# Altium schematic sheet Control_Schem.SchDoc(Control_Schem) (continued)
## Library data of the parts (continued)
U1 = BNO055: IMU ACCEL/GYRO/MAG I2C 28LGA / Intelligent 9-axis absolute orientation sensor; Manufacturer: Bosch Sensortec; Interface: I2C, UART; Max Supply Voltage: 3.6V; Min Supply Voltage: 1.7V; Operating Supply Current: 12.3mA; Ambient Temperature Range High: 85°C; Availability: Unavailable; Category: Inertial Measurement Units (IMUs); Component Kind: Standard; ComponentLink1Description: https://datasheet.octopart.com:443/BNO055-Bosch-Sensortec-datasheet-127364294.pdf?src-supplier=LKR; ComponentLink1URL: https://datasheet.octopart.com:443/BNO055-Bosch-Sensortec-datasheet-127364294.pdf?src-supplier=LKR; ComponentLink2Description: https://sigma.octopart.com:443/141945489/technical_drawing/Bosch-Sensortec-BNO055.pdf?src-supplier=Upverter; ComponentLink2URL: https://sigma.octopart.com:443/141945489/technical_drawing/Bosch-Sensortec-BNO055.pdf?src-supplier=Upverter; ComponentLink3Description: https://datasheet.octopart.com:443/BNO055-Bosch-Sensortec-datasheet-99733204.pdf?src-supplier=Upverter; ComponentLink3URL: https://datasheet.octopart.com:443/BNO055-Bosch-Sensortec-datasheet-99733204.pdf?src-supplier=Upverter; ComponentLink4Description: https://datasheet.octopart.com:443/BNO055-Bosch-Sensortec-datasheet-97529823.pdf?src-supplier=Upverter; ComponentLink4URL: https://datasheet.octopart.com:443/BNO055-Bosch-Sensortec-datasheet-97529823.pdf?src-supplier=Upverter; ComponentLink5Description: https://datasheet.octopart.com:443/BNO055-Bosch-Sensortec-datasheet-37535188.pdf?src-supplier=Upverter; ComponentLink5URL: https://datasheet.octopart.com:443/BNO055-Bosch-Sensortec-datasheet-37535188.pdf?src-supplier=Upverter; ComponentLink6Description: https://datasheet.octopart.com:443/BNO055-Bosch-Sensortec-datasheet-181953299.pdf?src-supplier=Future+Electronics; ComponentLink6URL: https://datasheet.octopart.com:443/BNO055-Bosch-Sensortec-datasheet-181953299.pdf?src-supplier=Future+Electronics; Height: 1.18mm; Library Name: Bosch_Sensor.SchLib; Manufacturer Part Number: BNO055; Max Operating Temperature: 85°C; Min Operating Temperature: -40°C; MP: BNO055; Package: None; Pins: 2; Price: None; Pricing: 4000=10.57 (USD); RoHS: Compliant; Supplier 1: Future Electronics; Supplier Part Number 1: BNO055
U2 = STM32F411RET6TR: RISC Microcontroller, 32-Bit, FLASH, CORTEX-M4 CPU, 100MHz, CMOS, PQFP64; Manufacturer: STMicroelectronics; ADC Channels (Resolution): 16-Ch 12-Bit; Clock Frequency: 26MHz; Core Architecture: ARM Cortex-M4; EEPROM Memory Size: 0B; Flash Memory Size: 512kB; Geometry.Height: 1.6mm; Interface: SDIO, USB; Max Supply Current: 34.5mA; Max Supply Voltage: 3.6V; Min Supply Voltage: 1.7V; Nominal Supply Current: 1.8µA; Number of A/D Converters: 1; Number of Channels: 16; Number of I2C Channels: 3; Number of I/Os: 50; Number of SPI Channels: 5; Number of Timers/Counters: 2; Operating Supply Voltage: 3.3V; Peripherals: POR; RAM Size: 128kB; Arrow Part Number: STM32F411RET6TR; Arrow Price/Stock: https://www.arrow.com/en/products/stm32f411ret6tr/stmicroelectronics?utm_currency=USD&region=nac; Case/Package: LFQFP; Category: Microcontrollers; China RoHS: Compliant; Component Kind: Standard; ComponentLink1Description: https://datasheet.octopart.com:443/STM32F411RET6TR-STMicroelectronics-datasheet-41919523.pdf?src-supplier=Upverter; ComponentLink1URL: https://datasheet.octopart.com:443/STM32F411RET6TR-STMicroelectronics-datasheet-41919523.pdf?src-supplier=Upverter; ComponentLink2Description: https://datasheet.octopart.com:443/STM32F411RET6TR-STMicroelectronics-datasheet-27102711.pdf?src-supplier=STMicroelectronics; ComponentLink2URL: https://datasheet.octopart.com:443/STM32F411RET6TR-STMicroelectronics-datasheet-27102711.pdf?src-supplier=STMicroelectronics; ComponentLink3Description: https://datasheet.octopart.com:443/STM32F411RET6TR-STMicroelectronics-datasheet-82511007.pdf?src-supplier=SHENGYU+ELECTRONICS; ComponentLink3URL: https://datasheet.octopart.com:443/STM32F411RET6TR-STMicroelectronics-datasheet-82511007.pdf?src-supplier=SHENGYU+ELECTRONICS; Country of Origin: Mainland China, Malaysia, Taiwan; Datasheet Link: https://www.st.com/resource/en/datasheet/stm32f411ce.pdf; Export Control Classification Number (ECCN) Code: 3A991.A.2; Frequency: 100MHz; Harmonized Tariff Schedule (HTS) Code: 8542.31.00.25; Height - Seated (Max): 1.6mm; Introduction Date: 2014-06-19; Length: 10mm; Library Name: ST_Microcontroller.SchLib; Lifecycle Status: Production; Manufacturer Part Number: STM32F411RET6TR; Manufacturer_Name: STMicroelectronics; Manufacturer_Part_Number: STM32F411RET6TR; Max Operating Temperature: 85°C; Memory Format: FLASH; Min Operating Temperature: -40°C; Mouser Part Number: 511-STM32F411RET6TR; Mouser Price/Stock: https://www.mouser.co.uk/ProductDetail/STMicroelectronics/STM32F411RET6TR?qs=BA62vJVifGpaf6czDatkOw%3D%3D; Number of Interrupts: 16; Number of Terminals: 64; Number of USART Channels: 3; Packaging: Tape and Reel; Pricing: 1=6.84, 10=5.258, 25=4.864, 100=4.4302, 250=4.2442 (USD); REACH SVHC: Yes; RoHS: Compliant; Stock: 34; Supplier 1: DigiKey; Supplier Part Number 1: 497-19349-1-ND; Temperature Grade: Industrial; Terminal Pitch: 500µm; Width: 10mm
U3 U4 = DRV8231ADSGR: 50-V, 4.1-A H-bridge motor driver with integrated current regulation & current-sense feedback 8-SO PowerPAD -40 to 150; Manufacturer: Texas Instruments; Manufacturer Lifecycle Status: ACTIVE; Number of Channels: 1; Category: Motor Drivers; Component Kind: Standard; ComponentLink2Description: https://datasheet.octopart.com:443/DRV8251ADDAR-Texas-Instruments-datasheet-165811235.pdf?src-supplier=ODG+(Origin+Data+Global); ComponentLink2URL: https://datasheet.octopart.com:443/DRV8251ADDAR-Texas-Instruments-datasheet-165811235.pdf?src-supplier=ODG+(Origin+Data+Global); ComponentLink3Description: https://datasheet.octopart.com:443/DRV8251ADDAR-Texas-Instruments-datasheet-178830255.pdf?src-supplier=LCSC; ComponentLink3URL: https://datasheet.octopart.com:443/DRV8251ADDAR-Texas-Instruments-datasheet-178830255.pdf?src-supplier=LCSC; ComponentLink4Description: http://sigma.octopart.com:80/reach_statement/Texas-Instruments-company-64.pdf?src-supplier=Compliance+Statements; ComponentLink4URL: http://sigma.octopart.com:80/reach_statement/Texas-Instruments-company-64.pdf?src-supplier=Compliance+Statements; ComponentLink5Description: http://sigma.octopart.com:80/conflict_mineral_statement/Texas-Instruments-company-63.pdf?src-supplier=Compliance+Statements; ComponentLink5URL: http://sigma.octopart.com:80/conflict_mineral_statement/Texas-Instruments-company-63.pdf?src-supplier=Compliance+Statements; Height: 1.7mm; Length: 4.89mm; Lifecycle Status: Production; Manufacturer Part Number: DRV8251ADDAR; Pins: 8; Pricing: 1=2.25, 10=1.665, 25=1.5196, 100=1.3591, 250=1.28268, 500=1.2366, 1000=1.19866 (USD); RoHS: Compliant; Stock: 6728; Supplier 1: DigiKey; Supplier Part Number 1: 296-DRV8251ADDARCT-ND; Thickness: 1.48mm; Width: 3.9mm; Library Name: Motion.SchLib
  U3: ComponentLink1Description: https://octopart.com:443/opatz8j6/c1?t=7oUKRKkqwphIgwbtNbBc67owxNExsRdXrlPd_sBHnIKi_-H_t5suGGb5ZND4dBnSpUprWKEI1GJbY9qXDqE5wQb54uF2_8cCtleVlQfIIUgNQal_f1XPPx41JvHuqZD8P686xjzcz29DRRHUNopMHhQt6Aun-Q7UtO3W5-WTfdM8Hgmb8mq14zsLVaf9BYQF4kWKKP1vJII8XnOUC2yw6q8reuoLVzCQkwxpJfqf3XVhJXuLLQnsgXc5Zvxap5_H4ldeiGenDzkE71_iLZElw_4WjFZC3t3acjBfqrvQXTF1uguQZXqacgCm7W9f8tf9yLwhTR4Pgx-V1MzeuQJKKgipRE97MCy_LxstRkR3sNYpAlDTbjfaO7-AnMMibebzN-J1q-mELw&src-supplier=Texas+Instruments; ComponentLink1URL: https://octopart.com:443/opatz8j6/c1?t=7oUKRKkqwphIgwbtNbBc67owxNExsRdXrlPd_sBHnIKi_-H_t5suGGb5ZND4dBnSpUprWKEI1GJbY9qXDqE5wQb54uF2_8cCtleVlQfIIUgNQal_f1XPPx41JvHuqZD8P686xjzcz29DRRHUNopMHhQt6Aun-Q7UtO3W5-WTfdM8Hgmb8mq14zsLVaf9BYQF4kWKKP1vJII8XnOUC2yw6q8reuoLVzCQkwxpJfqf3XVhJXuLLQnsgXc5Zvxap5_H4ldeiGenDzkE71_iLZElw_4WjFZC3t3acjBfqrvQXTF1uguQZXqacgCm7W9f8tf9yLwhTR4Pgx-V1MzeuQJKKgipRE97MCy_LxstRkR3sNYpAlDTbjfaO7-AnMMibebzN-J1q-mELw&src-supplier=Texas+Instruments
  U4: ComponentLink1Description: https://octopart.com:443/opatz8j6/c1?t=Gj9IDkYE8sfDnI1AQ8OPnHqI7H6pSVf5TjQsrTRNSfFb2i9Q4E7y6UU0tVNpv_uoOppLbHDr29Be0S0k4dsXsBj-9gVok0f8TON-7LqCOQIvnQH5XUUSK6qV1XxCoSC3IIyjzOJDbsjsD30C2G81fOEWgdAi9tTEL0YTqVgBlAxJkPUr1oD_orBTcTOlqy8LQjJndhkQh3dsRqZAKQuzGR54t0CVOThOeZ_uUhCqRvIOoCKY4GRRNIIIiOkvYVDQVjZDrzRxciuayJCFmhWe2bbu2HGZQ9cORHH4HLGk37RopXHYscM4bRc45aOsixNwKVZGCHcT521wi6UN6PXFqU74gjaycJJAvUxioH527eZvfdREOqnlpyx5aqlzelpdWEqVmK_K5A&src-supplier=Texas+Instruments; ComponentLink1URL: https://octopart.com:443/opatz8j6/c1?t=Gj9IDkYE8sfDnI1AQ8OPnHqI7H6pSVf5TjQsrTRNSfFb2i9Q4E7y6UU0tVNpv_uoOppLbHDr29Be0S0k4dsXsBj-9gVok0f8TON-7LqCOQIvnQH5XUUSK6qV1XxCoSC3IIyjzOJDbsjsD30C2G81fOEWgdAi9tTEL0YTqVgBlAxJkPUr1oD_orBTcTOlqy8LQjJndhkQh3dsRqZAKQuzGR54t0CVOThOeZ_uUhCqRvIOoCKY4GRRNIIIiOkvYVDQVjZDrzRxciuayJCFmhWe2bbu2HGZQ9cORHH4HLGk37RopXHYscM4bRc45aOsixNwKVZGCHcT521wi6UN6PXFqU74gjaycJJAvUxioH527eZvfdREOqnlpyx5aqlzelpdWEqVmK_K5A&src-supplier=Texas+Instruments
U5 = W25Q128JVSIQ: NOR Flash Serial (SPI, Dual SPI, Quad SPI) 3.3V 128M-bit 16M x 8 6ns 8-Pin SOIC Tube; Manufacturer: Winbond; Clock Frequency: 133MHz; Frequency: 104MHz; Max Supply Current: 20mA; Max Supply Voltage: 3.6V; Memory Size: 16MB; Min Supply Voltage: 2.7V; Number of Circuits: 1; Operating Supply Voltage: 3V; Ambient Temperature Range High: 85°C; Case/Package: SOP; Category: Flash; China RoHS: Compliant; Component Kind: Standard; ComponentLink1Description: https://datasheet.octopart.com:443/W25Q128JVSIQ-Winbond-datasheet-181529389.pdf?src-supplier=ODG+(Origin+Data+Global); ComponentLink1URL: https://datasheet.octopart.com:443/W25Q128JVSIQ-Winbond-datasheet-181529389.pdf?src-supplier=ODG+(Origin+Data+Global); ComponentLink2Description: https://sigma.octopart.com:443/138898685/technical_drawing/Winbond-W25Q128JVSIQ.pdf?src-supplier=Upverter; ComponentLink2URL: https://sigma.octopart.com:443/138898685/technical_drawing/Winbond-W25Q128JVSIQ.pdf?src-supplier=Upverter; ComponentLink3Description: https://datasheet.octopart.com:443/W25Q128JVSIQ-Winbond-datasheet-138898757.pdf?src-supplier=Upverter; ComponentLink3URL: https://datasheet.octopart.com:443/W25Q128JVSIQ-Winbond-datasheet-138898757.pdf?src-supplier=Upverter; ComponentLink4Description: https://datasheet.octopart.com:443/W25Q128JVSIQ-Winbond-datasheet-138104337.pdf?src-supplier=Augswan; ComponentLink4URL: https://datasheet.octopart.com:443/W25Q128JVSIQ-Winbond-datasheet-138104337.pdf?src-supplier=Augswan; Export Control Classification Number (ECCN) Code: EAR99; Harmonized Tariff Schedule (HTS) Code: 8542.32.00.51; Height: 2.16mm; Height - Seated (Max): 2.16mm; Interface: Serial; Introduction Date: 2016-11-14; Length: 5.23mm; Library Name: Memory.SchLib; Lifecycle Status: Production; Manufacturer Part Number: W25Q128JVSIQ; Max Operating Temperature: 85°C; Memory Format: FLASH; Min Operating Temperature: -40°C; Number of Terminals: 8; Pricing: 1=2.24, 10=2.097, 25=2.0376, 90=1.95322, 180=1.90739, 270=1.88059, 540=1.83506, 1080=1.78986, 5040=1.69147 (USD); REACH SVHC: Yes; RoHS: Compliant; Schedule B: 8542320070, 8542320070|8542320070, 8542320070|8542320070|8542320070, 8542320070|8542320070|8542320070|8542320070; Supplier 1: DigiKey; Supplier Part Number 1: W25Q128JVSIQ-ND; Temperature Grade: Industrial; Terminal Pitch: 1.27mm; Width: 5.23mm
X1 = XTAL-2P: Crystal 0.032768MHz ±20ppm (Tol) 12.5pF Flexural 70000Ohm 2-Pin CSMD T/R; Manufacturer: Abracon; Manufacturer Part Number: ABS07-32.768KHZ-T; ESR: 70kΩ; Frequency: 32.768kHz; Frequency Stability: 144ppm; Frequency Tolerance: 0.002%; Case/Package: CSMD; Category: Crystals; China RoHS: Compliant; Component Kind: Standard; ComponentLink1Description: https://datasheet.octopart.com:443/ABS07-32.768KHZ-T-Abracon-datasheet-166693031.pdf?src-supplier=JRH+Electronics; ComponentLink1URL: https://datasheet.octopart.com:443/ABS07-32.768KHZ-T-Abracon-datasheet-166693031.pdf?src-supplier=JRH+Electronics; ComponentLink2Description: https://datasheet.octopart.com:443/ABS07-32.768KHZ-T-Abracon-datasheet-103422647.pdf?src-supplier=JRH+Electronics; ComponentLink2URL: https://datasheet.octopart.com:443/ABS07-32.768KHZ-T-Abracon-datasheet-103422647.pdf?src-supplier=JRH+Electronics; ComponentLink3Description: https://datasheet.octopart.com:443/ABS07-32.768KHZ-T-Abracon-datasheet-12512028.pdf?src-supplier=Upverter; ComponentLink3URL: https://datasheet.octopart.com:443/ABS07-32.768KHZ-T-Abracon-datasheet-12512028.pdf?src-supplier=Upverter; ComponentLink4Description: https://datasheet.octopart.com:443/ABS07-32.768KHZ-T-Abracon-datasheet-10031075.pdf?src-supplier=Upverter; ComponentLink4URL: https://datasheet.octopart.com:443/ABS07-32.768KHZ-T-Abracon-datasheet-10031075.pdf?src-supplier=Upverter; ComponentLink5Description: https://datasheet.octopart.com:443/ABS07-32.768KHZ-T-Abracon-datasheet-8326266.pdf?src-supplier=Upverter; ComponentLink5URL: https://datasheet.octopart.com:443/ABS07-32.768KHZ-T-Abracon-datasheet-8326266.pdf?src-supplier=Upverter; ComponentLink6Description: https://datasheet.octopart.com:443/ABS07-32.768KHZ-T-Abracon-datasheet-121082.pdf?src-supplier=Upverter; ComponentLink6URL: https://datasheet.octopart.com:443/ABS07-32.768KHZ-T-Abracon-datasheet-121082.pdf?src-supplier=Upverter; ComponentLink7Description: https://datasheet.octopart.com:443/ABS07-32.768KHZ-T-Abracon-datasheet-41191559.pdf?src-supplier=element14+APAC; ComponentLink7URL: https://datasheet.octopart.com:443/ABS07-32.768KHZ-T-Abracon-datasheet-41191559.pdf?src-supplier=element14+APAC; ComponentLink8Description: https://datasheet.octopart.com:443/ABS07-32.768KHZ-T-Abracon-datasheet-15206620.pdf?src-supplier=Newark; ComponentLink8URL: https://datasheet.octopart.com:443/ABS07-32.768KHZ-T-Abracon-datasheet-15206620.pdf?src-supplier=Newark; ComponentLink9Description: https://datasheet.octopart.com:443/ABS07-32.768KHZ-T-Abracon-datasheet-37124839.pdf?src-supplier=Farnell; ComponentLink9URL: https://datasheet.octopart.com:443/ABS07-32.768KHZ-T-Abracon-datasheet-37124839.pdf?src-supplier=Farnell; ComponentLink10Description: https://datasheet.octopart.com:443/ABS07-32.768KHZ-T-Abracon-datasheet-128558976.pdf?src-supplier=X-ON; ComponentLink10URL: https://datasheet.octopart.com:443/ABS07-32.768KHZ-T-Abracon-datasheet-128558976.pdf?src-supplier=X-ON; ComponentLink11Description: https://datasheet.octopart.com:443/ABS07-32.768KHZ-T-Abracon-datasheet-49826663.pdf?src-supplier=TME; ComponentLink11URL: https://datasheet.octopart.com:443/ABS07-32.768KHZ-T-Abracon-datasheet-49826663.pdf?src-supplier=TME; ComponentLink12Description: https://datasheet.octopart.com:443/ABS07-32.768KHZ-T-Abracon-datasheet-15994676.pdf?src-supplier=TME; ComponentLink12URL: https://datasheet.octopart.com:443/ABS07-32.768KHZ-T-Abracon-datasheet-15994676.pdf?src-supplier=TME; ComponentLink13Description: http://sigma.octopart.com:80/conflict_mineral_statement/Abracon-company-27.pdf?src-supplier=Compliance+Statements; ComponentLink13URL: http://sigma.octopart.com:80/conflict_mineral_statement/Abracon-company-27.pdf?src-supplier=Compliance+Statements; Country of Origin: Mainland China; Depth: 1.5mm; ESR (Equivalent Series Resistance): 70kΩ; Export Control Classification Number (ECCN) Code: EAR99; Harmonized Tariff Schedule (HTS) Code: 8541.60.00.10; Height: 900µm; Introduction Date: 2007-01-08; Lead Free: Lead Free; Length: 3.2mm; Library Name: Standard.SchLib; Lifecycle Status: Production; Load Capacitance: 12.5pF; Max Operating Temperature: 85°C; Min Operating Temperature: -40°C; Mounting Technology: Surface Mount; Packaging: Tape and Reel; Pins: 2; Pricing: 3000=0.199, 6000=0.184, 9000=0.179, 12000=0.155 (USD); Radiation Hardening: No; REACH SVHC: Yes; RoHS: Compliant; Schedule B: 8541600025, 8541600025|8541600025, 8541600025|8541600025|8541600025, 8541600025|8541600025|8541600025|8541600025; Stock: 1691934; Supplier 1: Master Electronics; Supplier Part Number 1: ABS07-32.768KHZ-T; Termination: SMD/SMT; Tolerance: 0.002%; Value: 32k768Hz; Width: 1.5494mm
X2 = XTAL-4P: Oscillator XO 24MHz ±50ppm 15pF CMOS 55% 1.8V/2.5V/3.3V 4-Pin SMD T/R; Manufacturer: Epson; Fall Time: 3ns; Low Level Output Current: 6mA; Max Supply Current: 2.2mA; Max Supply Voltage: 3.3V; Min Supply Voltage: 1.6V; Ratings: IATF 16949; Case/Package: SMD/SMT; Category: Crystals; China RoHS: Compliant; Component Kind: Standard; ComponentLink1Description: https://datasheet.octopart.com:443/SG-210STF-24.0000ML0-Epson-datasheet-68297300.pdf?src-supplier=Electro+Sonic; ComponentLink1URL: https://datasheet.octopart.com:443/SG-210STF-24.0000ML0-Epson-datasheet-68297300.pdf?src-supplier=Electro+Sonic; ComponentLink2Description: https://datasheet.octopart.com:443/SG-210STF-24.0000ML0-Epson-datasheet-164319076.pdf?src-supplier=Arrow.cn; ComponentLink2URL: https://datasheet.octopart.com:443/SG-210STF-24.0000ML0-Epson-datasheet-164319076.pdf?src-supplier=Arrow.cn; ComponentLink3Description: https://datasheet.octopart.com:443/SG-210STF24.0000ML0-Epson-datasheet-189100305.pdf?src-supplier=Master+Electronics; ComponentLink3URL: https://datasheet.octopart.com:443/SG-210STF24.0000ML0-Epson-datasheet-189100305.pdf?src-supplier=Master+Electronics; ComponentLink4Description: http://sigma.octopart.com:80/149135108/reach_statement/Epson-SG-210STF-24.0000ML0.pdf?src-supplier=Compliance+Statements; ComponentLink4URL: http://sigma.octopart.com:80/149135108/reach_statement/Epson-SG-210STF-24.0000ML0.pdf?src-supplier=Compliance+Statements; ComponentLink5Description: http://sigma.octopart.com:80/149135109/rohs_statement/Epson-SG-210STF-24.0000ML0.pdf?src-supplier=Compliance+Statements; ComponentLink5URL: http://sigma.octopart.com:80/149135109/rohs_statement/Epson-SG-210STF-24.0000ML0.pdf?src-supplier=Compliance+Statements; Country of Origin: Japan, Mainland China, Malaysia, Thailand; Export Control Classification Number (ECCN) Code: EAR99; Frequency: 24MHz; Frequency Stability: 50ppm; Height: 889µm; Introduction Date: 2014-01-28; Length: 2.4892mm; Library Name: Standard.SchLib; Lifecycle Status: Production; Load Capacitance: 15pF; Manufacturer Part Number: SG-210STF 24.0000ML0; Max Operating Temperature: 85°C; Min Operating Temperature: -40°C; Mounting Technology: Surface Mount; Number of Terminals: 4; Packaging: Tape & Reel (TR); Pricing: 1000=0.335, 2000=0.325 (USD); REACH SVHC: Yes; Rise Time: 3ns; RoHS: Compliant; Schedule B: 8541600060, 8541600060|8541600060|8541600060|8541600060|8541600060; Stock: 1000; Supplier 1: Future Electronics; Supplier Part Number 1: SG-210STF 24.0000ML0; Width: 2.0066mm
J1 = STDC14_DebugConnector: Component Kind: Standard; Library Name: ST_Microcontroller.SchLib; Supplier 1: DigiKey
TP1-TP8 = TP: Library Name: Standard.SchLib
M1 M2 = Pololu_MicroMetal: Library Name: Motion.SchLib
